# Altium schematic sheet Simple_Project.SchDoc
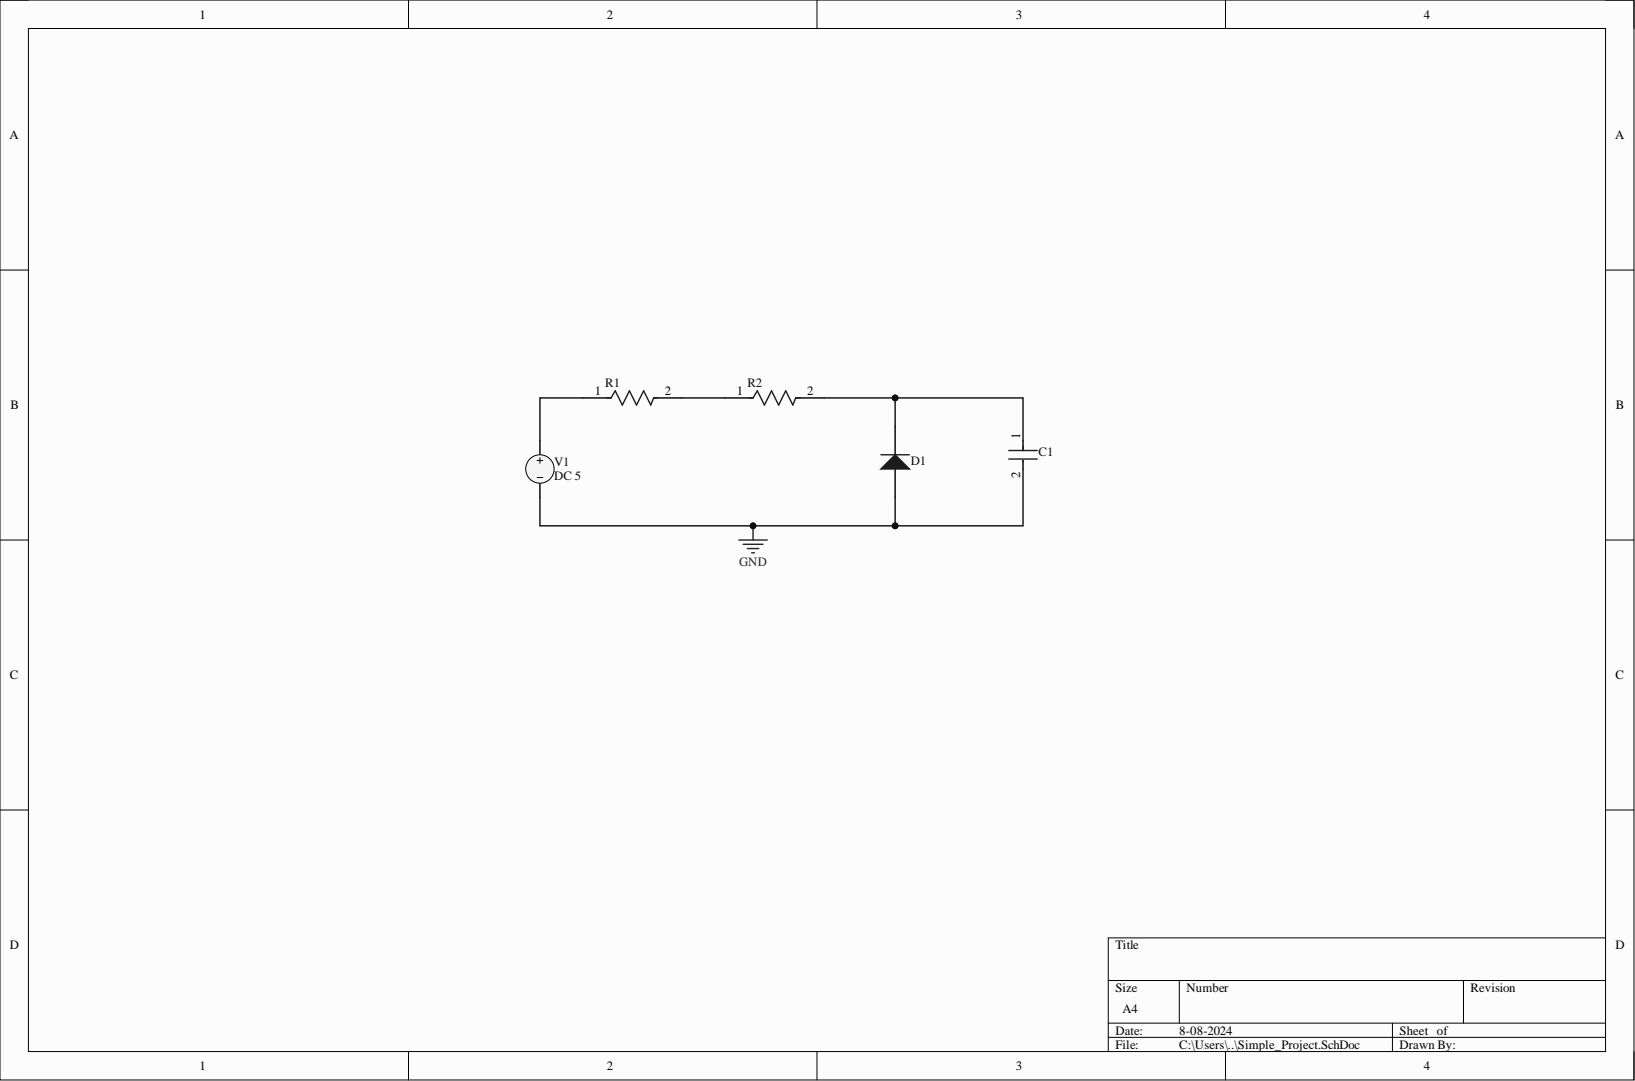
[diagram p0: Simple_Project.SchDoc]

## Parts
C1  GRM188R60J106ME47D  [FP-GRM188-0_1-e0_2_0_55-IPC_A]  (value not drawn)
D1  FDLL4148  [FAIR-LL-34_V]  (value not drawn)
R1  MRS25000C1001FCT00  [FP-MRS25-MFG]  (value not drawn)
R2  MRS25000C1001FCT00  [FP-MRS25-MFG]  (value not drawn)
V1  DC 5  value 5

## Nets
GND: C1.2 D1.1 V1.2
NetC1_1: C1.1 D1.2 R2.2
NetR1_1: R1.1 V1.1
NetR1_2: R1.2 R2.1

## Library data of the parts
C1 = CMP-2006-02792-2: Chip Multilayer Ceramic Capacitors for General Purpose, 0603, 10uF, X5R, 15%, 20%, 6.3V; Capacitance: 10uF; Case Code (Imperial): 0603; Case Code (Metric): 1608; Case/Package: 0603; Component Kind: Standard; Depth: 0.8mm; Dielectric: X5R; Height: 0.8mm; Lead Free: Lead Free; Length: 1.6mm; Library Name: Altium Content Vault; Material: Ceramic; Max Operating Temperature: 85°C; Min Operating Temperature: -55°C; Mount: Surface Mount; Package Quantity: 4000; Packaging: Tape and Reel; Radiation Hardening: No; REACH SVHC: No SVHC; Termination: SMD/SMT; Thickness: 0.035inch; Tolerance: 20%; Voltage: 6.3V; Voltage Rating: 6.3V; Voltage Rating (DC): 6.3V; Width: 0.031inch
D1 = CMP-2000-05112-2: High Conductance Fast Diode, 500 mW, 100 V, -65 to 175 degC, 2-Pin SMD, RoHS, Tape and Reel; Manufacturer: FairchildSemiconductor; Configuration: Single; Peak Average Forward Current: 0.3A; Peak Forward Voltage: 1@0.01AV; Peak Non-Repetitive Surge Current: 4A; Peak Reverse Current: 5@75VuA; Peak Reverse Recovery Time: 4ns; Peak Reverse Repetitive Voltage: 100V; Rad Hard: No; Type: SmallSignalSwitchingDiode; Category: Rectifier; Component Kind: Standard; Datasheet URL: https://datasheet.ciiva.com/8053/30071-8053638.pdf; Datasheet Version: Nov-96; Library Name: Altium Content Vault; Manufacturer URL: https://www.fairchildsemi.com/; Mounting: SurfaceMount; Operating Temperature: -65to175degC; Package: 2LL-34; Package Description: 2-Leads SOD, Body 3.6x1.5mm; Package URL: https://www.fairchildsemi.com/package-drawings/SO/SOD80A.pdf; Packaging: Tape&Reel
R1 R2 = CMP-02408-000051-1: RES 1K OHM 0.6W 1% AXIAL; Lead Diameter: 0.6mm; Max Power Dissipation: 600mW; Resistance: 1kR; Temperature Coefficient: 50ppm/°C; Voltage Rating: 350V; Case/Package: Axial; Component Kind: Standard; Construction: Cylindrical; Contact Plating: Tin; Diameter: 2.5mm; Lead Free: Lead Free; Length: 6.5mm; Library Name: Altium Content Vault; Max Operating Temperature: 155°C; Military Standard: Not; Min Operating Temperature: -55°C; Mount: Through Hole; Number of Pins: 2; Number of Terminations: 2; Package Quantity: 5000; Packaging: Tape and Reel; Power Rating: 600mW; Radiation Hardening: No; Resistance Tolerance: 1%; Resistor Type: Metal Film; RoHS Compliant: Yes; Series: MRS25; Technology: THIN FILM; Termination: Axial; Tolerance: 1%; Voltage Rating (DC): 350V; Weight: 0.00776oz; Width: 2.5mm
V1 = VSRC: Voltage Source; AC Magnitude: 1; AC Phase: 0; Note: PCB Footprint - Not required; Component Kind: Standard; LatestRevisionDate: 17-Jul-2002; LatestRevisionNote: Re-released for DXP Platform.; Library Name: Simulation Generic Components.IntLib; PackageReference: Not Applicable; Published: 8-Jun-2000; Simulation: VSRC; Publisher: Altium Limited
